# Altium schematic sheet Debug.SchDoc(Debug)
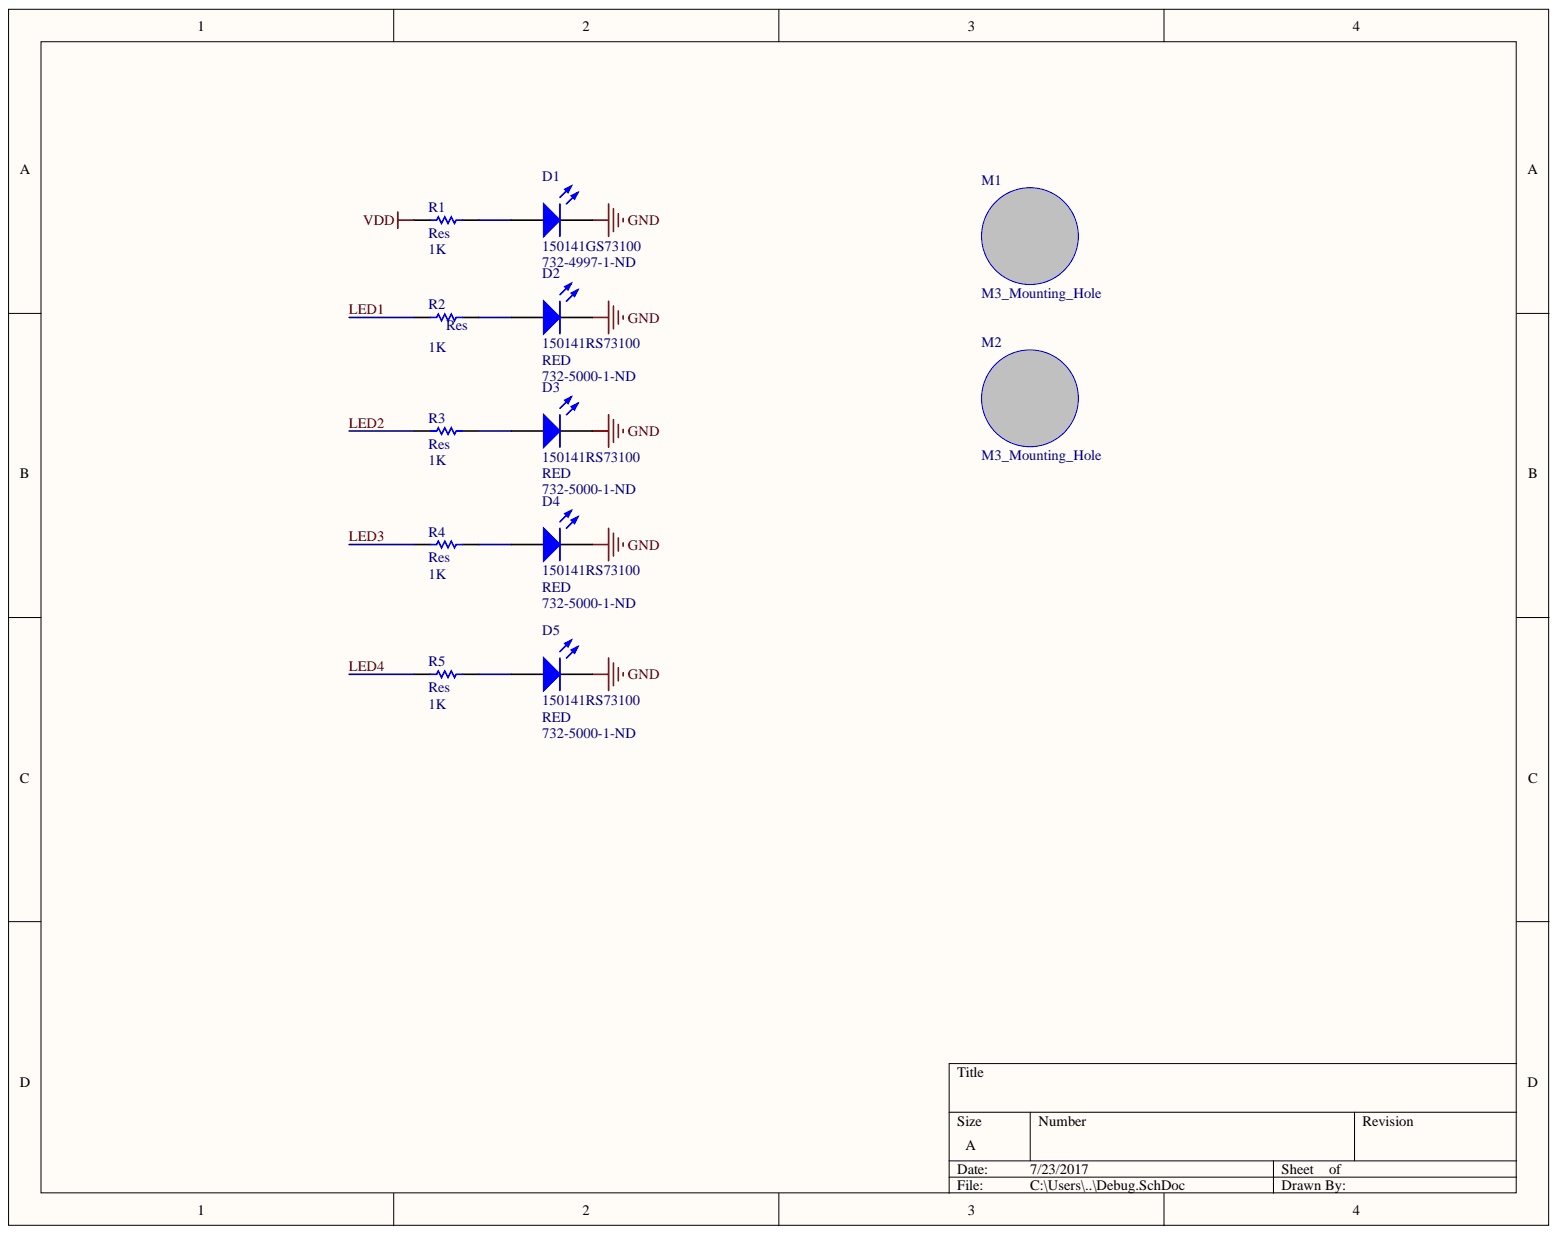
[diagram p0: Debug.SchDoc]

## Parts
D1  150141GS73100  [150141RS73100]
D2  150141RS73100  [150141RS73100]
D3  150141RS73100  Supplier Part Number 2: 732-5000-1-ND  Colour: RED  [150141RS73100]
D4  150141RS73100  Supplier Part Number 2: 732-5000-1-ND  Colour: RED  [150141RS73100]
D5  150141RS73100  Colour: RED  [150141RS73100]
M1  M3_Mounting_Hole  [M3_Mounting_Hole]
M2  M3_Mounting_Hole  [M3_Mounting_Hole]
R1  Res  value 1K  [3216[1206]]
R2  Res  value 1K  [3216[1206]]
R3  Res  value 1K  [3216[1206]]
R4  Res  value 1K  [3216[1206]]
R5  Res  value 1K  [3216[1206]]

## Nets
GND: D1.2 D2.2 D3.2 D4.2 D5.2
VDD: R1.1
LED1: R2.1
LED2: R3.1
LED3: R4.1
LED4: R5.1
NetD1_1: D1.1 R1.2
NetD2_1: D2.1 R2.2
NetD3_1: D3.1 R3.2
NetD4_1: D4.1 R4.2
NetD5_1: D5.1 R5.2

## Library data of the parts
D1 = 150141GS73100: Typical GREEN LED; Manufacturer: Würth Elektronik (Wuerth Elektronik); Wavelength: 525; Viewing Angle: 120; Test Current: 20; Luminous Intensity: 1000; Lens Style: Round,Clear; Forward Voltage: 3.1; Forward Current: 30; Width: 2.8; Supplier Part Number 1: 732-4997-1-ND; Supplier 1: Digikey; Stock 1: 572; REACH SVHC: Unknown; Pricing 1: 1=0.17, 100=0.149, 500=0.113 (USD); Packaging: Cut Tape; Package Quantity: 2000; Min Operating Temperature: -40; Max Operating Temperature: 85; Manufacturer Part Number: 150141GS73100; Library Name: FC.SchLib; Length: 3.5; Lead Free: Lead Free; Height: 1.9; Dominant Wavelength: 525; ComponentLink6URL: https://datasheet.ciiva.com/29278/759463-da-01-en-smd-topled-einf-gruen-150141gs73100-29278953.pdf; ComponentLink6Description: https://datasheet.ciiva.com/29278/759463-da-01-en-smd-topled-einf-gruen-150141gs73100-29278953.pdf; ComponentLink5URL: https://datasheet.ciiva.com/48430/150141gs73100-eng-tds-48430060.pdf; ComponentLink5Description: https://datasheet.ciiva.com/48430/150141gs73100-eng-tds-48430060.pdf; ComponentLink4URL: https://datasheet.ciiva.com/29563/150141gs73100-29563030.pdf; ComponentLink4Description: https://datasheet.ciiva.com/29563/150141gs73100-29563030.pdf; ComponentLink3URL: https://datasheet.ciiva.com/29563/150141gs73100-29563029.pdf; ComponentLink3Description: https://datasheet.ciiva.com/29563/150141gs73100-29563029.pdf; ComponentLink2URL: https://datasheet.ciiva.com/22656/1911484-22656997.pdf; ComponentLink2Description: https://datasheet.ciiva.com/22656/1911484-22656997.pdf; ComponentLink1URL: https://datasheet.ciiva.com/23843/150141gs73100-23843266.pdf; ComponentLink1Description: https://datasheet.ciiva.com/23843/150141gs73100-23843266.pdf; Component Kind: Standard; Colour: Green; Case Code (Metric): 3528; Case Code (Imperial): 1411; Case/Package: 1411
D2-D5 = 150141RS73100: Typical RED LED; Manufacturer: Würth Elektronik (Wuerth Elektronik); Wavelength: 625; Viewing Angle: 120; Test Current: 20; Luminous Intensity: 300; Lens Style: Round,Clear; Forward Voltage: 2; Forward Current: 30; Width: 2.8; Supplier 2: Digikey; Supplier 1: Digi-Key; Stock 2: 5335; REACH SVHC: Unknown; Published: 8-Jun-2000; Pricing 2: 1=0.17, 100=0.149, 500=0.113 (USD); Packaging: Tape and Reel; PackageReference: 3.2X1.6X1.1; Package Quantity: 2000; PackageDocument: Feb-2002; Min Operating Temperature: -40; Max Operating Temperature: 85; Manufacturer Part Number: 150141RS73100; Library Name: FC.SchLib; Length: 3.5; LatestRevisionNote: Re-released for DXP Platform.; LatestRevisionDate: 17-Jul-2002; Height: 1.9; Dominant Wavelength: 625; ComponentLink4URL: https://datasheet.ciiva.com/29278/759464-da-01-en-smd-topled-einf-rot-150141rs73100-29278947.pdf; ComponentLink4Description: https://datasheet.ciiva.com/29278/759464-da-01-en-smd-topled-einf-rot-150141rs73100-29278947.pdf; ComponentLink3URL: https://datasheet.ciiva.com/29563/150141rs73100-29563032.pdf; ComponentLink3Description: https://datasheet.ciiva.com/29563/150141rs73100-29563032.pdf; ComponentLink2URL: https://datasheet.ciiva.com/29563/150141rs73100-29563031.pdf; ComponentLink2Description: https://datasheet.ciiva.com/29563/150141rs73100-29563031.pdf; ComponentLink1URL: https://datasheet.ciiva.com/29562/150141rs73100-29562993.pdf; ComponentLink1Description: https://datasheet.ciiva.com/29562/150141rs73100-29562993.pdf; Component Kind: Standard; Case Code (Metric): 3528; Case Code (Imperial): 1411; Case/Package: 1411; Publisher: Altium Limited
  D2: Supplier Part Number 2: 732-5000-1-ND; Colour: RED
  D5: Supplier Part Number 2: 732-5000-1-ND
R1-R5 = Res: Surface Mount Resistor; Published: 8-Jun-2000; PackageReference: AXIAL-0.5; Library Name: Resistors.IntLib; LatestRevisionNote: Re-released for DXP Platform.; LatestRevisionDate: 17-Jul-2002; Component Kind: Standard; Publisher: Altium Limited
M1 M2 = M3_Mounting_Hole: Library Name: FC.SchLib; Component Kind: Standard
